# Altium schematic sheet try1.SchDoc(try1)
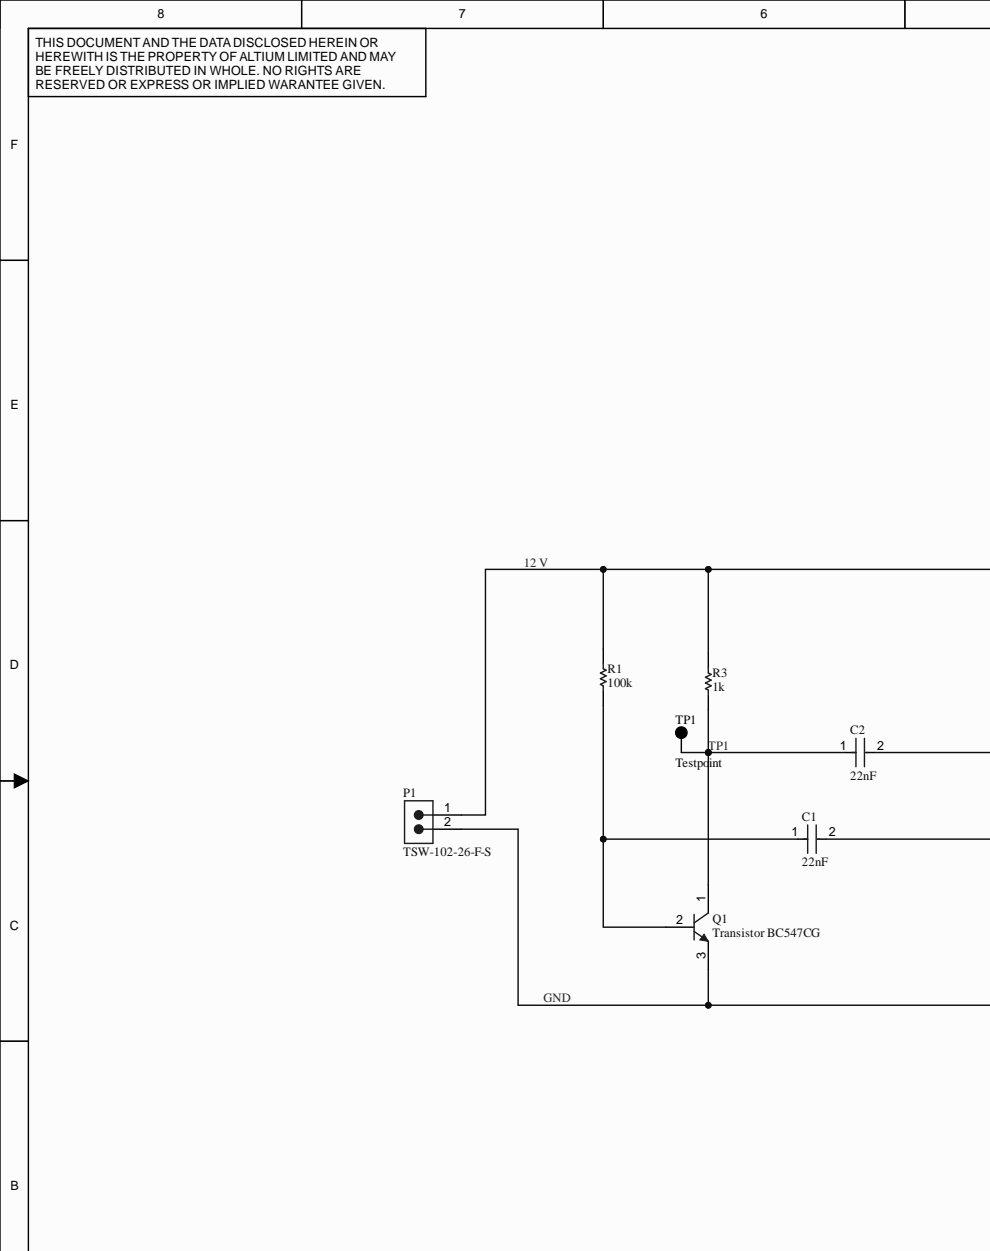
[diagram p0: try1.SchDoc, left]
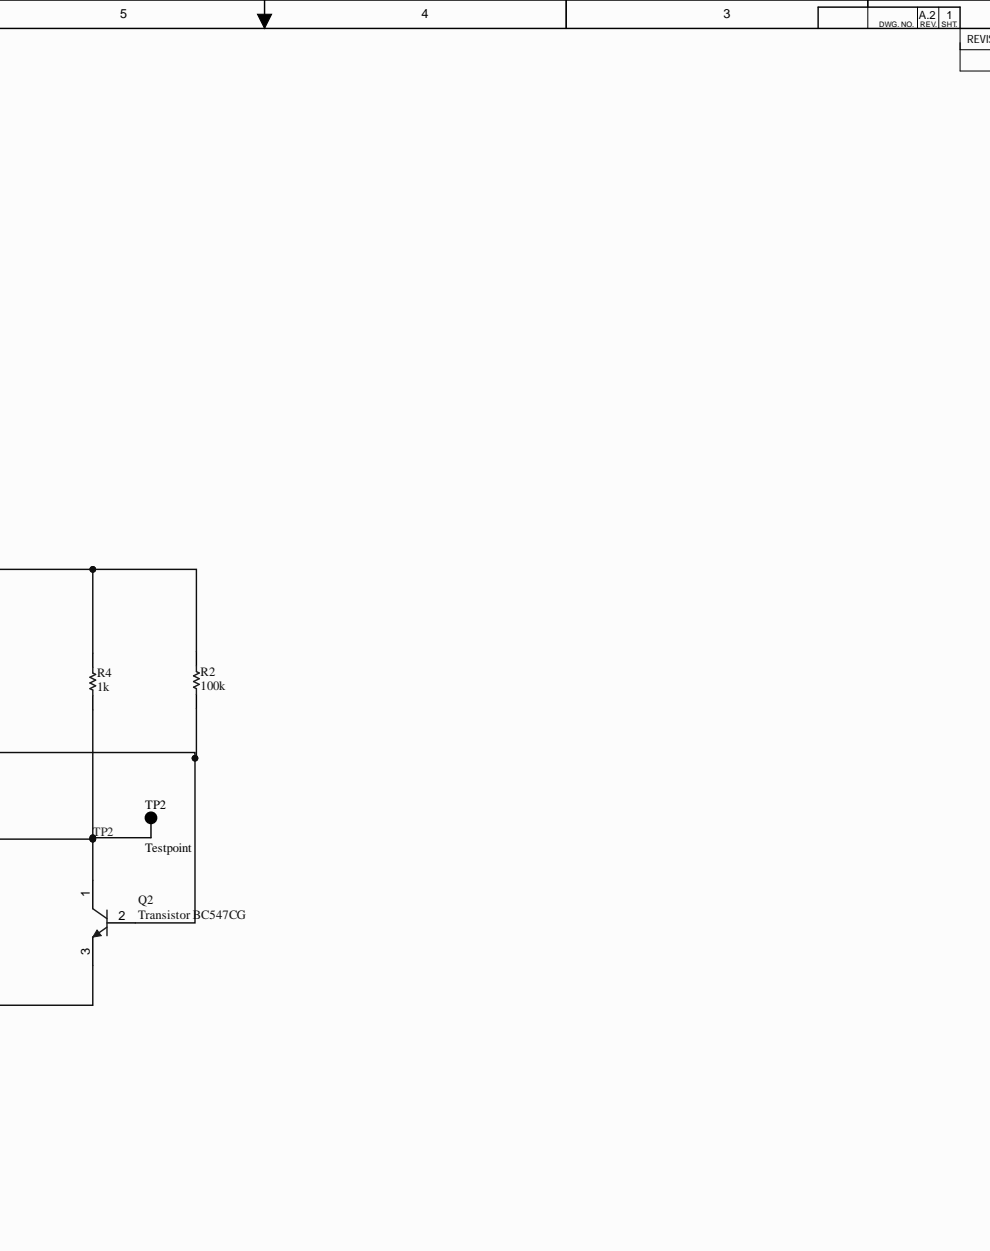
[diagram p1: try1.SchDoc, right]

## Parts
C1  value 22nF  [FP-C0603C-CF-IPC_A]  (hidden comment: Capacitor 22nF +/-5% 16V 0603.)
C2  value 22nF  [FP-C0603C-CF-IPC_A]  (hidden comment: Capacitor 22nF +/-5% 16V 0603.)
P1  TSW-102-26-F-S  [SMTC-TSW-102-26-XX-S]
Q1  Transistor BC547CG  [ONSC-TO-92-3-29-11]
Q2  Transistor BC547CG  [ONSC-TO-92-3-29-11]
R1  value 100k  [RESC2013X70X40ML20T20]  (hidden comment: Resistor 100K +/-5% 0805 125 mW.)
R2  value 100k  [RESC2013X70X40ML20T20]  (hidden comment: Resistor 100K +/-5% 0805 125 mW.)
R3  value 1k  [RESC2013X70X40ML20T20]  (hidden comment: Resistor 1K +/-5% 0805 500 mW.)
R4  value 1k  [RESC2013X70X40ML20T20]  (hidden comment: Resistor 1K +/-5% 0805 500 mW.)
TP1  Testpoint  [KSTN-5011_V]
TP2  Testpoint  [KSTN-5011_V]

## Nets
12 V: P1.1 R1.2 R2.2 R3.2 R4.2
GND: P1.2 Q1.3 Q2.3
TP1: C2.1 Q1.1 R3.1 TP1.1
TP2: C1.2 Q2.1 R4.1 TP2.1
NetC1_1: C1.1 Q1.2 R1.1
NetC2_2: C2.2 Q2.2 R2.1

## Library data of the parts
C1 C2 = CMP-001-00083-1: Ceramic Capacitor, Multilayer, Ceramic, 16V, 5% +Tol, 5% -Tol, X7R, 15% TC, 0.022uF, Surface Mount, 0603; Multilayer: Yes; Capacitor Type: Ceramic; Case/Package: 0603; Component Kind: Standard; Dielectric Material: Ceramic; Height: 0.8mm; JESD-609 Code: e3; Length: 1.6mm; Library Name: ELEC 391; Max Operating Temperature: 125°C; Min Operating Temperature: -55°C; Mounting Technology: Surface Mount; Package Shape: Rectangular; Package Style: SMTMeter; Packaging: Tape and Reel; Pins: 2; Rated DC Voltage (URdc): 16V; Reach SVHC Compliant: Yes; RoHS Compliant: Yes; Temperature Characteristics Code: X7R; Terminal Finish: Nickel; Termination: Wraparound; Tolerance  (fill in as +-): 5%; Tolerance (fill in as +-): 5%; Width: 0.8mm
P1 = CMP-002-00072-1: Board Connector, 2 Contact(s), 1 Row(s), Male, Straight, 0.1 inch Pitch, Solder Terminal, Black Insulator; Additional Feature: E.L.P.; Contact Pattern: RECTANGULAR; Contact Style: SQ PIN-SKT; DIN Conformance: No; Mating Information: MULTIPLE MATING PARTS AVAILABLE; Number Of Connectors: 1; Number of Contacts: 2; Option: General Purpose; Plating Thickness: 3uin; Reference Standard: UL; Reliability: COMMERCIAL; Body Breadth: 0.1inch; Body Depth: 0.1inch; Component Kind: Standard; Connector Type: BOARD CONNECTOR; Contact Finish - Mating: GOLD FLASH OVER NICKEL (50); Contact Finish - Termination: Tin (Sn) - with Nickel (Ni) barrier; Contact Gender: Male; Contact Material: Bronze; Filter Feature: No; Flammability Rating: UL94 V-0; IEC Conformance: No; Insulation Color: Black; Insulation Material: Polybutylene; JESD-609 Code: e3; Library Name: ELEC 391; Mating Contact Pitch: 0.1inch; MIL Conformance: No; Mixed Contacts: No; Mounting Style: STRAIGHT; Mounting Technology: Board; Number Of PCB Rows: 1; Number of Rows Loaded: 1; PCB Contact Pattern: RECTANGULAR; Reach SVHC Compliant: Yes; RoHS Compliant: Yes; Terminal Length: 0.126inch; Terminal Pitch: 2.54mm; Termination: Solder
Q1 Q2 = CMP-013-00036-1: Small Signal Bipolar Transistor, 0.1A I(C), 45V V(BR)CEO, 1-Element, NPN, Silicon, TO-92; DC Current Gain-Min (hFE): 420; Frequency: 300MHz; Max Collector Current: 100mA; Number of Elements: 1; Package Body Material: Plastic; Power Dissipation-Max (Abs): 625mW; Qualification Status: Not Qualified; Surface Mount: No; Time @ Peak Reflow Temperature-Max (s): 40s; Transistor Application: AMPLIFIER; Collector-emitter Voltage-Max: 45V; Component Kind: Standard; JEDEC-95 Code: TO-92; JESD-30 Code: O-PBCY-T3; JESD-609 Code: e1; Library Name: ELEC 391; Max Operating Temperature: 150°C; Package Shape: Round; Package Style: CYLINDRICALMeter; Peak Reflow Temperature (Cel): 260°C; Pins: 3; Polarity/Channel Type: NPN; Reach SVHC Compliant: Yes; RoHS Compliant: Yes; Terminal Finish: Copper; Terminal Form: Through Hole; Terminal Position: BOTTOM; Transistor Element Material: SILICON
R1 R2 = CMP-009-00181-1: Fixed Resistor, Metal Glaze/thick Film, 0.125W, 100000ohm, 150V, 5% +/-Tol, 200ppm/Cel, Surface Mount, 0805; Package Height: 0.6mm; Package Length: 2mm; Package Width: 1.25mm; Power: 125mW; Rated Temperature: 70°C; Reference Standard: AEC-Q200; Temperature Coefficient: 200ppm/°C; Case/Package: 0805; Component Kind: Standard; Construction: Rectangular; JESD-609 Code: e3; Library Name: ELEC 391; Max Operating Temperature: 155°C; Min Operating Temperature: -55°C; Mounting Technology: Surface Mount; Package Shape: Rectangular; Package Style: SMTMeter; Packaging: Tape and Reel; Pins: 2; Reach SVHC Compliant: Yes; Resistor Type: FIXED RESISTOR; RoHS Compliant: Yes; Technology: METAL GLAZE/THICK FILM; Terminal Finish: Nickel; Termination: Wraparound; Tolerance: 5%; Working Voltage: 150V
R3 R4 = CMP-009-00182-1: Fixed Resistor, Metal Glaze/thick Film, 0.5W, 1000ohm, 400V, 5% +/-Tol, 200ppm/Cel, Surface Mount, 0805; Package Height: 0.6mm; Package Length: 2mm; Package Width: 1.25mm; Power: 500mW; Rated Temperature: 70°C; Reference Standard: AEC-Q200; Temperature Coefficient: 200ppm/°C; Case/Package: 0805; Component Kind: Standard; Construction: Rectangular; JESD-609 Code: e3; Library Name: ELEC 391; Max Operating Temperature: 155°C; Min Operating Temperature: -55°C; Mounting Technology: Surface Mount; Package Shape: Rectangular; Package Style: SMTMeter; Packaging: Tape and Reel; Pins: 2; Reach SVHC Compliant: Yes; Resistor Type: FIXED RESISTOR; RoHS Compliant: Yes; Technology: METAL GLAZE/THICK FILM; Terminal Finish: Tin; Termination: Wraparound; Tolerance: 5%; Working Voltage: 400V
TP1 TP2 = CMP-002-00073-1: Test Point MultiPurpose THM H .445 Nylon 46 Insulated Blk PhosBronze/Silver; Body Material: PBT; Gender: Female; Hole Diameter: 1.6mm; Inside Diameter: 1.78mm; Color: Black; Component Kind: Standard; Contact Material: Bronze; Contact Plating: Tin,Silver; Flammability Rating: UL94 V-0; Lead Free: Lead Free; Length: 11.3mm; Library Name: ELEC 391; Material: Nylon,Bronze,Silver; Mounting Technology: PCB,Through Hole; Package Quantity: 100; Packaging: Bulk; Reach SVHC Compliant: No; RoHS Compliant: Yes; Series: THM
